# Altium schematic sheet Analog Front End.SchDoc
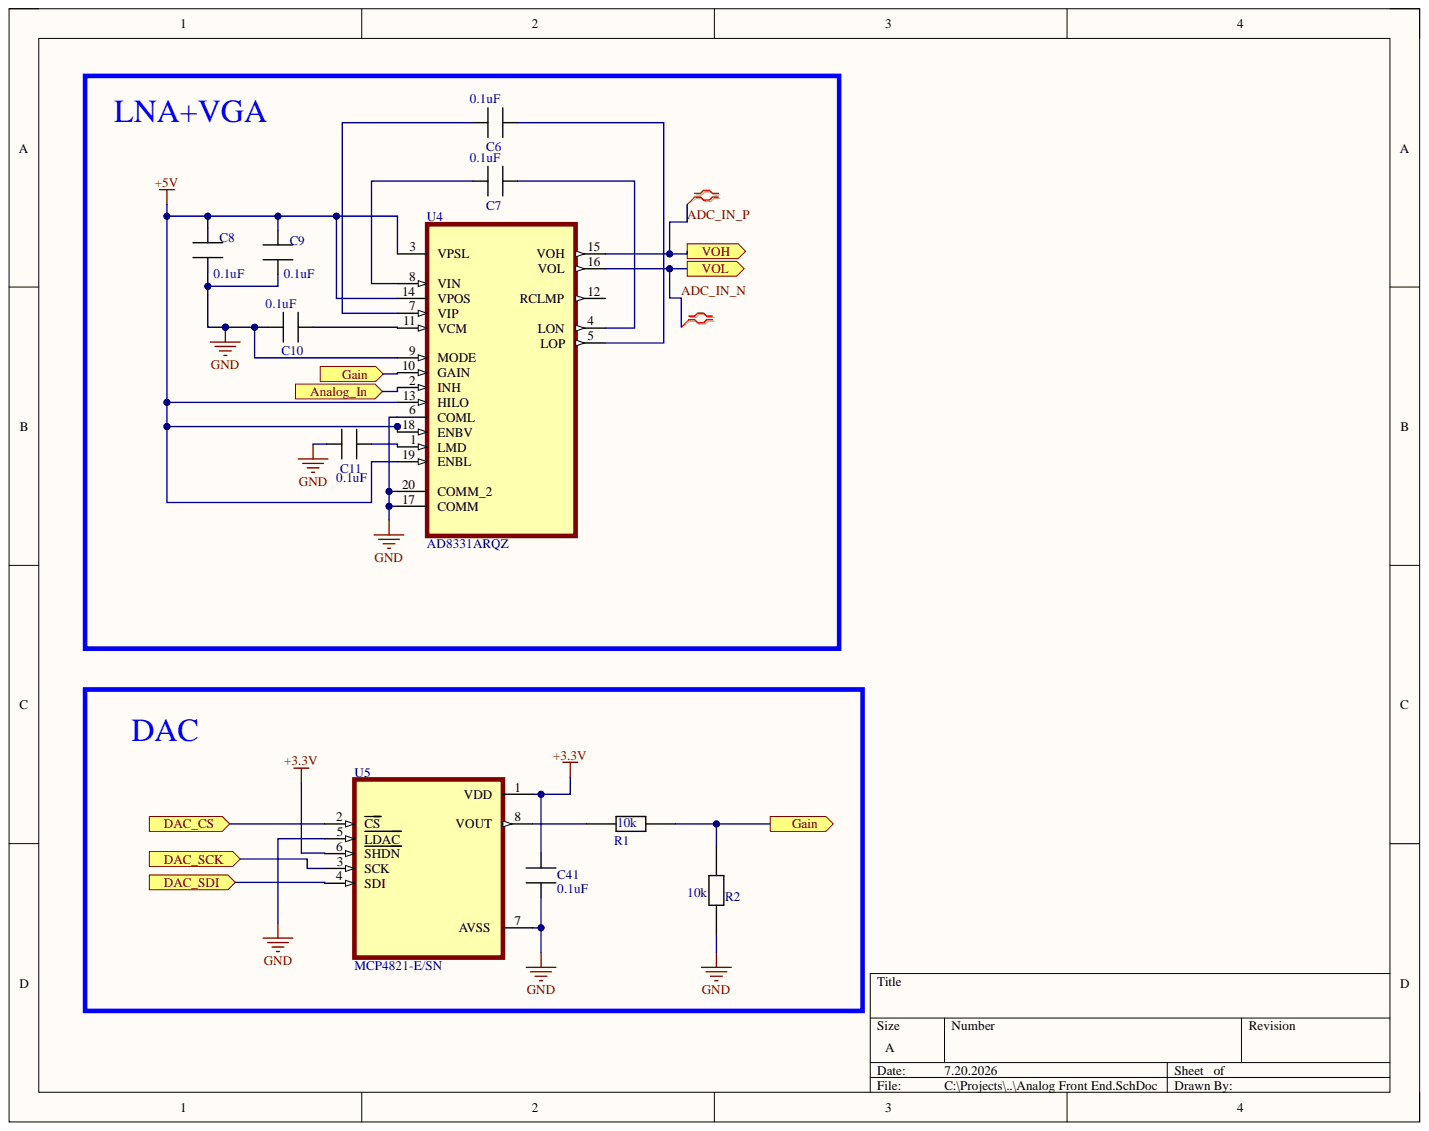
[diagram p0: Analog Front End.SchDoc]

## Parts
C6  0.1uF  [CAP_0805]
C7  0.1uF  [CAP_0805]
C8  0.1uF  [CAP_0805]
C9  0.1uF  [CAP_0805]
C10  0.1uF  [CAP_0805]
C11  0.1uF  [CAP_0805]
C41  0.1uF  [CAP_0805]
R1  10k  [RES_0805]
R2  10k  [RES_0805]
U4  AD8331ARQZ  [SOP64P600X165-20N]
U5  MCP4821-E/SN  [SOIC127P600X175-8N]

## Ports
Analog_In, DAC_CS, DAC_SCK, DAC_SDI, Gain, VOH, VOL

## Nets
+3.3V: C41.2 U5.1(VDD) U5.6(SHDN)
+5V: C8.2 C9.2 U4.3(VPSL) U4.13(HILO) U4.14(VPOS) U4.18(ENBV) U4.19(ENBL)
GND: C8.1 C9.1 C10.1 C11.1 C41.1 R2.1 U4.6(COML) U4.9(MODE) U4.17(COMM) U4.20(COMM_2) U5.5(LDAC) U5.7(AVSS)
ADC_IN_N: U4.16(VOL) ; port VOL
ADC_IN_P: U4.15(VOH) ; port VOH
Analog_In: U4.2(INH) ; port Analog_In
DAC_CS: U5.2(CS) ; port DAC_CS
DAC_SCK: U5.3(SCK) ; port DAC_SCK
DAC_SDI: U5.4(SDI) ; port DAC_SDI
Gain: R1.2 R2.2 U4.10(GAIN) ; port Gain
NetC6_1: C6.1 U4.7(VIP)
NetC6_2: C6.2 U4.5(LOP)
NetC7_1: C7.1 U4.8(VIN)
NetC7_2: C7.2 U4.4(LON)
NetC10_2: C10.2 U4.11(VCM)
NetC11_2: C11.2 U4.1(LMD)
NetR1_1: R1.1 U5.8(VOUT)

Not connected: U4 12(RCLMP)

## Library data of the parts
C6-C11 C41 = CAP_0805: note: any manufacturer; Component Kind: Standard; Description: CAP_0805; Library Name: Passives.SchLib; val: 0.1uF
R1 R2 = RES_0805: note: any manufacturer; Component Kind: Standard; Description: RES_0805; Library Name: Passives.SchLib; val: 10k
U4 = AD8331ARQZ: Single VGA with Ultralow Noise Preamplifier and Programmable RIN; MF: Analog Devices; Check_prices: https://www.snapeda.com/parts/AD8331ARQZ/Analog+Devices/view-part/?ref=eda; Component Kind: Standard; Library Name: IC_Digital.SchLib; MP: AD8331ARQZ; Package: QSOP-20 Analog Devices; SnapEDA_Link: https://www.snapeda.com/parts/AD8331ARQZ/Analog+Devices/view-part/?ref=snap
U5 = MCP4821-E/SN: SINGLE 12-BIT DAC WITH SPI INTERACE AND INTERNAL VREF, SOIC-8 | Microchip Technology Inc. MCP4821-E/SN; Check_prices: https://www.snapeda.com/parts/MCP4821-E/SN/Microchip+Technology/view-part/?ref=eda; Component Kind: Standard; Library Name: IC_Digital.SchLib; MF: Microchip; MP: MCP4821-E/SN; Package: SOIC-8 Microchip; SnapEDA_Link: https://www.snapeda.com/parts/MCP4821-E/SN/Microchip+Technology/view-part/?ref=snap
